# Altium schematic sheet 2.8_tftlcd_spi.SchDoc
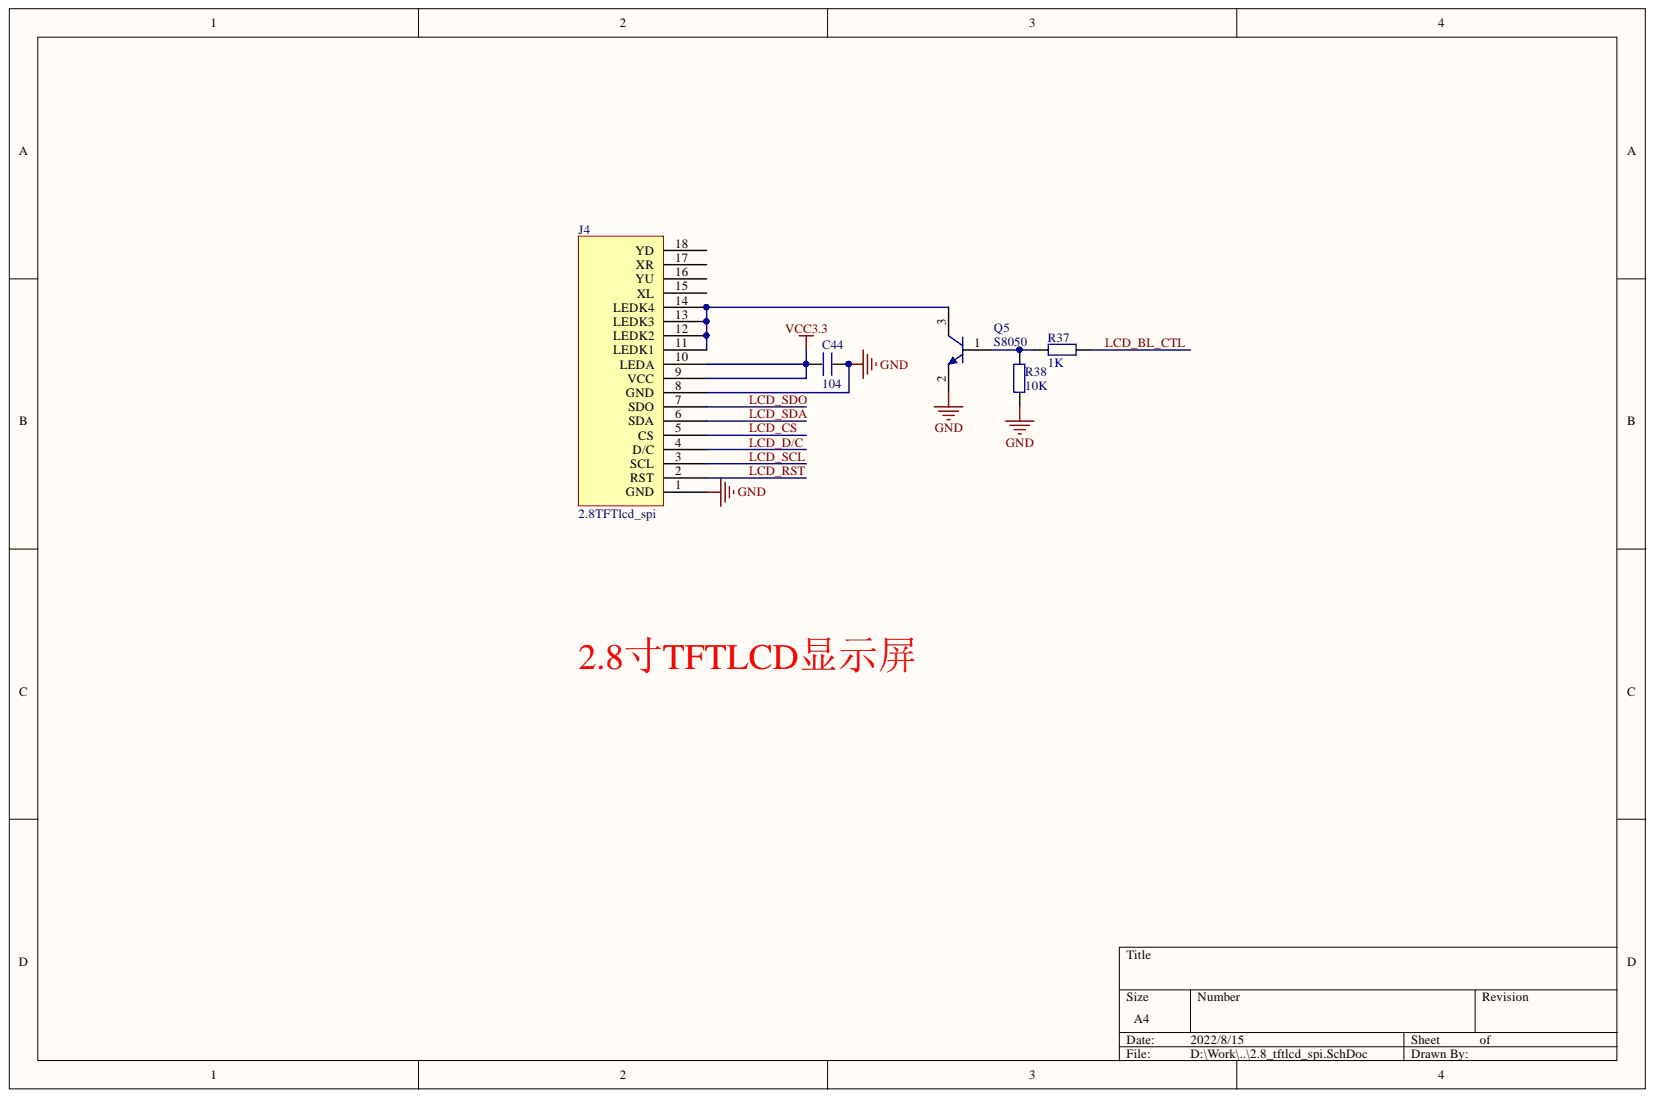
[diagram p0: 2.8_tftlcd_spi.SchDoc]

## Parts
C44  104  [0603C]
J4  2.8TFTlcd_spi  [2.8]
Q5  S8050  [SOT-23]
R37  value 1K  [0603R]  (hidden comment: Res2)
R38  value 10K  [0603R]  (hidden comment: Res2)

## Nets
GND: C44.1 J4.1(GND) J4.8(GND) Q5.2 R38.2
VCC3.3: C44.2 J4.9(VCC) J4.10(LEDA)
LCD_BL_CTL: R37.1
LCD_CS: J4.5(CS)
LCD_D/C: J4.4(D/C)
LCD_RST: J4.2(RST)
LCD_SCL: J4.3(SCL)
LCD_SDA: J4.6(SDA)
LCD_SDO: J4.7(SDO)
NetJ4_11: J4.11(LEDK1) J4.12(LEDK2) J4.13(LEDK3) J4.14(LEDK4) Q5.3
NetQ5_1: Q5.1 R37.2 R38.1

Not connected: J4 15(XL) 16(YU) 17(XR) 18(YD)

## Library data of the parts
C44 = C: Component Kind: Standard; Library Name: WarShip V3 STM32103封装库.IntLib
Q5 = NPN: Component Kind: Standard; Description: NPN; Library Name: Basic.SchLib
R37 R38 = Res2: Resistor; Component Kind: Standard; LatestRevisionDate: 17-Jul-2002; LatestRevisionNote: Re-released for DXP Platform.; PackageDescription: Axial Device, Thru-Hole; 2 Leads; 0.4 in Pin Spacing; PackageReference: AXIAL-0.4; Published: 8-Jun-2000; Library Name: 常用器件库.IntLib; Publisher: Altium Limited
J4 = 2.8TFTlcd_spi: Component Kind: Standard; Library Name: WIFI气象站.SchLib
